# Altium schematic sheet DAC and MIC.SchDoc
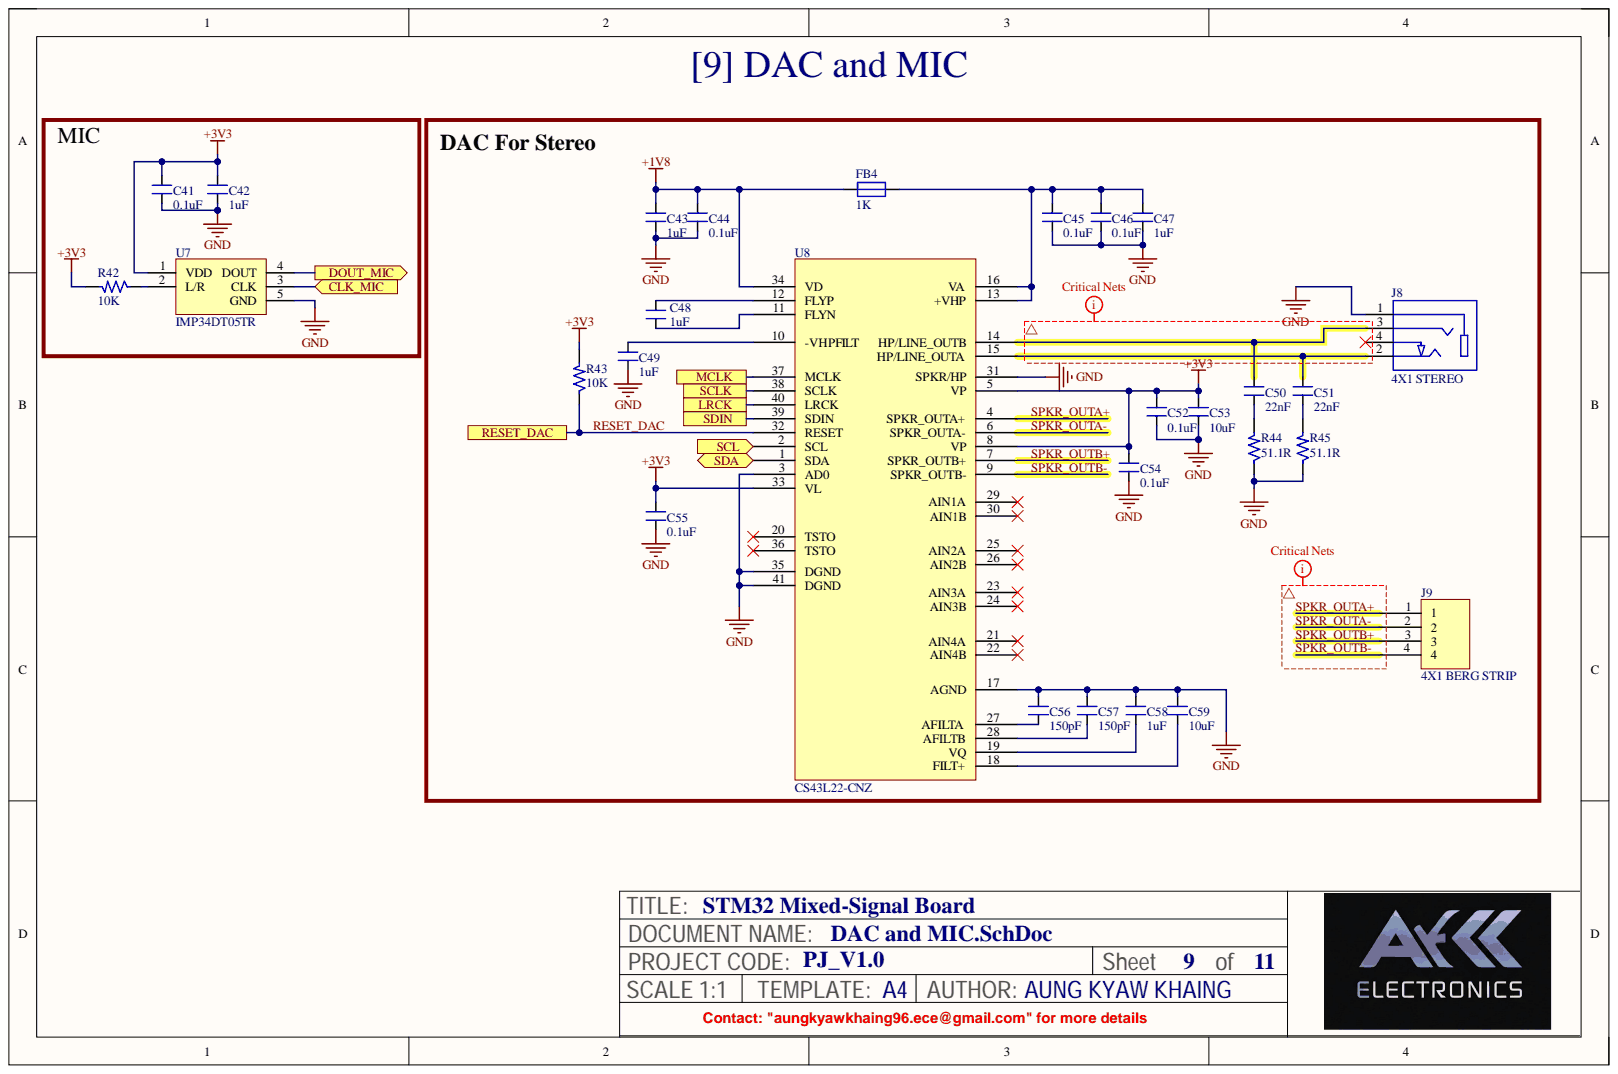
[diagram p0: DAC and MIC.SchDoc]

## Parts
C41  0.1uF  [CAP_0805]
C42  1uF  [CAP_0805]
C43  1uF  [CAP_0805]
C44  0.1uF  [CAP_0805]
C45  0.1uF  [CAP_0805]
C46  0.1uF  [CAP_0805]
C47  1uF  [CAP_0805]
C48  1uF  [CAP_0805]
C49  1uF  [CAP_0805]
C50  22nF  [CAP_0805]
C51  22nF  [CAP_0805]
C52  0.1uF  [CAP_0805]
C53  10uF  [CAP_1206]
C54  0.1uF  [CAP_0805]
C55  0.1uF  [CAP_0805]
C56  150pF  [CAP_0805]
C57  150pF  [CAP_0805]
C58  1uF  [CAP_0805]
C59  10uF  [CAP_1206]
FB4  1K  [IND_0805]
J8  4X1 STEREO  [CON_SJ-2504A-SMT-TR_SMD]
J9  4X1 BERG STRIP  [CON4_100_40D72_TH_ST_MALE]
R42  10K  [RES_0603]
R43  10K  [RES_0603]
R44  51.1R  [RES_0603]
R45  51.1R  [RES_0603]
U7  IMP34DT05TR  [HCLGA-4_53_137_15X37_SMD]
U8  CS43L22-CNZ  [QFN40_19_284_33X9_SMD]

## Ports
CLK_MIC, DOUT_MIC, LRCK, MCLK, RESET_DAC, SCL, SCLK, SDA, SDIN

## Nets
+1V8: C43.2 C44.2 FB4.1 U8.34(VD)
+3V3: C41.2 C42.2 C52.2 C53.2 C54.2 C55.2 R42.2 R43.2 U7.1(VDD) U8.5(VP) U8.8(VP) U8.33(VL)
GND: C41.1 C42.1 C43.1 C44.1 C45.1 C46.1 C47.1 C49.1 C52.1 C53.1 C54.1 C55.1 C56.2 C57.2 C58.2 C59.2 J8.1 R44.1 R45.1 U7.5(GND) U8.3(AD0) U8.17(AGND) U8.31(SPKR/HP) U8.35(DGND) U8.41(DGND)
CLK_MIC: U7.3(CLK) ; port CLK_MIC
DOUT_MIC: U7.4(DOUT) ; port DOUT_MIC
LRCK: U8.40(LRCK) ; port LRCK
MCLK: U8.37(MCLK) ; port MCLK
RESET_DAC: R43.1 U8.32(RESET) ; port RESET_DAC
SCL: U8.2(SCL) ; port SCL
SCLK: U8.38(SCLK) ; port SCLK
SDA: U8.1(SDA) ; port SDA
SDIN: U8.39(SDIN) ; port SDIN
SPKR_OUTA+: J9.1 U8.4(SPKR_OUTA+)
SPKR_OUTA-: J9.2 U8.6(SPKR_OUTA-)
SPKR_OUTB+: J9.3 U8.7(SPKR_OUTB+)
SPKR_OUTB-: J9.4 U8.9(SPKR_OUTB-)
NetC45_2: C45.2 C46.2 C47.2 FB4.2 U8.13(+VHP) U8.16(VA)
NetC48_1: C48.1 U8.11(FLYN)
NetC48_2: C48.2 U8.12(FLYP)
NetC49_2: C49.2 U8.10(-VHPFILT)
NetC50_1: C50.1 R44.2
NetC50_2: C50.2 J8.3 U8.14(HP/LINE_OUTB)
NetC51_1: C51.1 R45.2
NetC51_2: C51.2 J8.2 U8.15(HP/LINE_OUTA)
NetC56_1: C56.1 U8.27(AFILTA)
NetC57_1: C57.1 U8.28(AFILTB)
NetC58_1: C58.1 U8.19(VQ)
NetC59_1: C59.1 U8.18(FILT+)
NetR42_1: R42.1 U7.2(L/R)

Not connected: J8 4; U8 20(TSTO) 21(AIN4A) 22(AIN4B) 23(AIN3A) 24(AIN3B) 25(AIN2A) 26(AIN2B) 29(AIN1A) 30(AIN1B) 36(TSTO)

## Library data of the parts
C41 C44-C46 C52 C54 C55 = CAP_0.1uF-50V-10%-0805: 0.1µF ±10% 50V Ceramic Capacitor X7R 0805 (2012 Metric); Alternate Part Number-1: NA; Manufacturer: Samsung; Manufacturer Part Number: CL21B104KBCNNNC; Case/Package: 0805; Component Kind: Standard; Library Name: ESTEEMPCBLIB.IntLib; Supplier Part Number-1: 0805B104K500CT; Supplier-1: SIRICOM
C42 C43 C47-C49 C58 = CAP_1uF-50V-5%-0805: 1µF ±5% 50V Ceramic Capacitor X7R 0805 (2012 Metric); Alternate Part Number-1: 0805F105M500NT; Manufacturer: Samsung; Manufacturer Part Number: CC0805JKX7R9BB105; Case/Package: 0805; Component Kind: Standard; Library Name: ESTEEMPCBLIB.IntLib; Supplier Part Number-1: CL21B105KBFNNNE; Supplier-1: SIRICOM
C50 C51 = CAP_22nF-50V-10%-0805: 0.022µF ±10% 50V Ceramic Capacitor X7R 0805 (2012 Metric); Alternate Part Number-1: NA; Manufacturer: Samsung Electro-Mechanics; Manufacturer Part Number: CL21B223KBANNNC; Case/Package: 0805; Component Kind: Standard; Library Name: ESTEEMPCBLIB.IntLib; Supplier Part Number-1: 1276-1081-1-ND - Cut Tape (CT); Supplier-1: Digikey
C53 C59 = CAP_10uF-50V-10%-1206: 10µF ±10% 50V Ceramic Capacitor X7R 1206 (3216 Metric); Alternate Part Number-1: GRT31CR61H106ME01L; Manufacturer: KEMET; Manufacturer Part Number: CL31B106KBHNNNE; Case/Package: 1206; Component Kind: Standard; Library Name: ESTEEMPCBLIB.IntLib; Supplier Part Number-1: CC1206KKX5R9BB106; Supplier-1: SIRICOM
C56 C57 = CAP_150pF-50V-10%-0805: 150pF ±10% 50V Ceramic Capacitor C0G, NP0 0805 (2012 Metric); Alternate Part Number-1: NA; Manufacturer: AVX Corporation; Manufacturer Part Number: 08055A151KAT2A; Case/Package: 0805; Component Kind: Standard; Library Name: ESTEEMPCBLIB.IntLib; Supplier Part Number-1: 478-10501-1-ND - Cut Tape (CT); Supplier-1: Digikey
FB4 = FB_1K-100MHZ-1.6A-0805: 1 kOhms @ 100MHz 1 Power Line Ferrite Bead 0805 (2012 Metric) 1.6A; Alternate Part Number-1: NA; Manufacturer: Laird; Manufacturer Part Number: BLM21SP102SH1D; Case/Package: 0805; Component Kind: Standard; Library Name: ESTEEMPCBLIB.IntLib; Supplier Part Number-1: ACMS201209A102; Supplier-1: SIRICOM
J8 = CON-PLG-STEREO_4X1-100-RA-SMD: 2.50mm (0.094", 3/32", Sub Mini, Miniature) - Headphone Phone Jack Stereo (4 Conductor, TRRS) Connector Solder; Alternate Part Number-1: NA; Manufacturer: CUI Devices; Manufacturer Part Number: SJ-2504A-SMT-TR; Case/Package: SMD; Component Kind: Standard; Library Name: ESTEEMPCBLIB.IntLib; Supplier Part Number-1: CP-SJ-2504A-SMT-CT-ND - Cut Tape (CT); Supplier-1: Digikey
J9 = CON-BS-PLG_4X1-100-ST-TH: 4 Pins, 1 Row 2.54mm Pitch, Straight bergstrip, Male; Alternate Part Number-1: NA; Case/Package: TH-CON; Component Kind: Standard; Library Name: ESTEEMPCBLIB.IntLib; Manufacturer: NA; Manufacturer Part Number: NA; Supplier Part Number-1: NA; Supplier-1: SP ROAD
R42 R43 = RES_10K-0.1W-1%-0603: 10 kOhms ±1% 0.1W, 1/10W Chip Resistor 0603 (1608 Metric) Automotive AEC-Q200 Thick Film; Alternate Part Number-1: 0603WAJ0103T5E; Manufacturer: Walsin; Manufacturer Part Number: WR06X1002FTL; Case/Package: 0603; Component Kind: Standard; Library Name: ESTEEMPCBLIB.IntLib; Supplier Part Number-1: WR06X1002FTL; Supplier-1: SIRICOM
R44 R45 = RES_51.1R-0.1W-1%-0603: 51.1 Ohms ±1% 0.1W, 1/10W Chip Resistor 0603 (1608 Metric) Thick Film; Alternate Part Number-1: NA; Manufacturer: Vishay Dale; Manufacturer Part Number: CRCW060351R1FKEAC; Case/Package: 0603; Component Kind: Standard; Library Name: ESTEEMPCBLIB.IntLib; Supplier Part Number-1: 541-5468-1-ND - Cut Tape (CT); Supplier-1: Digikey
U7 = IC_IMP34DT05TR: MEMS Microphones AUTO & INDUSTRIAL SENSORS; Alternate Part Number-1: NA; Manufacturer: STMicroelectronics; Case/Package: HCLGA-4; Component Kind: Standard; Library Name: ESTEEMPCBLIB.IntLib; Manufacturer Part Number: IMP34DT05TR; Supplier Part Number-1: 511-IMP34DT05TR; Supplier-1: Mouser
U8 = IC_CS43L22: Audio D/A Converter ICs Low Power Stereo DAC w/ClassD Spkr Driver; Alternate Part Number-1: NA; Manufacturer: Cirrus Logic; Case/Package: QFN-40; Component Kind: Standard; Library Name: ESTEEMPCBLIB.IntLib; Manufacturer Part Number: CS43L22-CNZ; Supplier Part Number-1: 777-CS43L22-CNZ; Supplier-1: Mouser
